# Altium schematic sheet Hierarchy.SchDoc
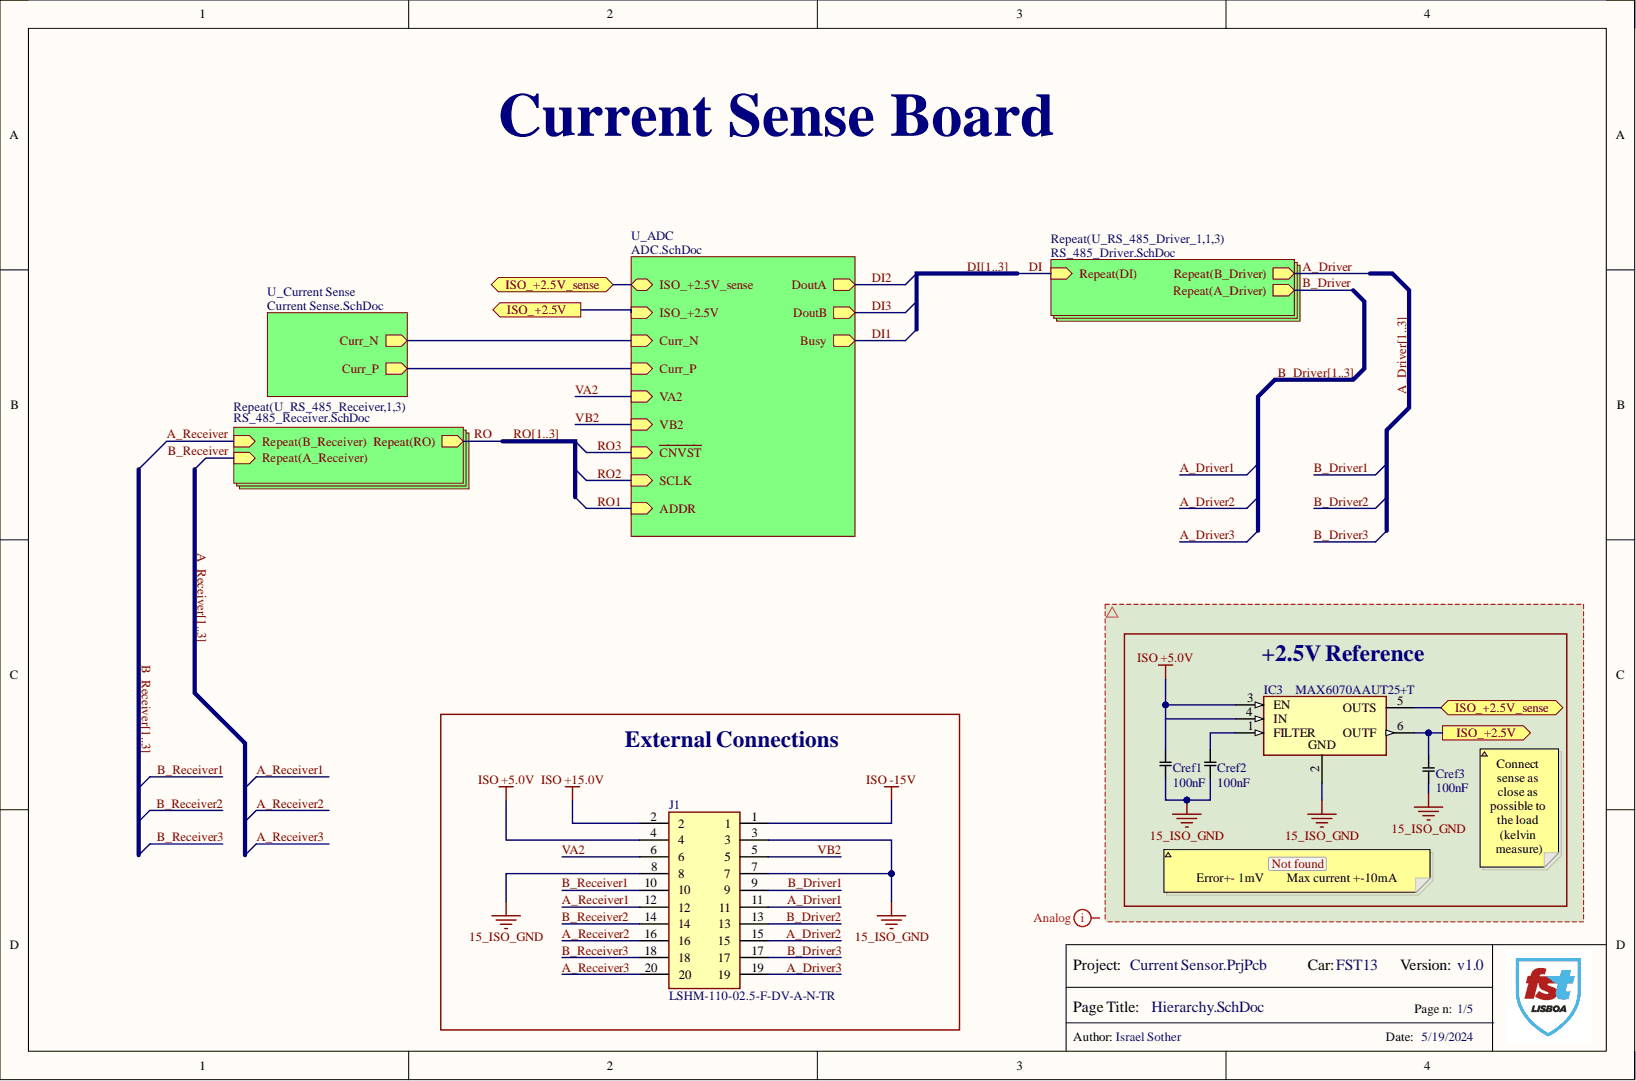
[diagram p0: Hierarchy.SchDoc]

## Parts
Cref1  Capacitance: 100nF  [0603]  (hidden comment: Capacitor 100nF 0603)
Cref2  Capacitance: 100nF  [0603]  (hidden comment: Capacitor 100nF 0603)
Cref3  Capacitance: 100nF  [0603]  (hidden comment: Capacitor 100nF 0603)
IC3  MAX6070AAUT25+T  [SOT95P280X145-6N]
J1  LSHM-110-02.5-F-DV-A-N-TR  [LSHM-110-XX.X-YYY-DV-A-N-TR]

## Ports
ISO_+2.5V, ISO_+2.5V_sense

## Nets
15_ISO_GND: Cref1.1 Cref2.1 Cref3.1 IC3.2 J1.3 J1.7 J1.8
ISO +5.0V: Cref1.2 IC3.3(EN) IC3.4(IN) J1.4
ISO +15.0V: J1.2
ISO -15V: J1.1
A_Driver1: J1.11  [also labelled A_Driver]
A_Driver2: J1.15  [also labelled A_Driver]
A_Driver3: J1.19  [also labelled A_Driver]
A_Receiver1: J1.12  [also labelled A_Receiver]
A_Receiver2: J1.16  [also labelled A_Receiver]
A_Receiver3: J1.20  [also labelled A_Receiver]
B_Driver1: J1.9  [also labelled B_Driver]
B_Driver2: J1.13  [also labelled B_Driver]
B_Driver3: J1.17  [also labelled B_Driver]
B_Receiver1: J1.10  [also labelled B_Receiver]
B_Receiver2: J1.14  [also labelled B_Receiver]
B_Receiver3: J1.18  [also labelled B_Receiver]
ISO_+2.5V: Cref3.2 IC3.6(OUTF) ; port ISO_+2.5V
ISO_+2.5V_sense: IC3.5(OUTS) ; port ISO_+2.5V_sense
VA2: J1.6
VB2: J1.5
NetCref2_2: Cref2.2 IC3.1(FILTER)
Curr_N:
Curr_P:
DI1:  [also labelled DI]
DI2:  [also labelled DI]
DI3:  [also labelled DI]
RO1:  [also labelled RO]
RO2:  [also labelled RO]
RO3:  [also labelled RO]

## Library data of the parts
Cref1-Cref3 = Capacitor: Component Kind: Standard; Description: Capacitor; Library Name: InverterSchematicLib.SchLib
IC3 = MAX6070AAUT25+T: Integrated Circuit; Manufacturer_Name: Analog Devices; Arrow Part Number: MAX6070AAUT25+T; Arrow Price/Stock: https://www.arrow.com/en/products/max6070aaut25t/maxim-integrated?region=nac; Component Kind: Standard; Datasheet Link: https://datasheets.maximintegrated.com/en/ds/MAX6070-MAX6071.pdf; Height: 1.45mm; Library Name: SamacSys.SchLib; Manufacturer_Part_Number: MAX6070AAUT25+T; Mouser Part Number: 700-MAX6070AAUT25+T; Mouser Price/Stock: https://www.mouser.co.uk/ProductDetail/Maxim-Integrated/MAX6070AAUT25%2bT?qs=CEvMt6SrWlLKXVYOZsN3AA%3D%3D
J1 = LSHM-110-02.5-F-DV-A-N-TR: Connector; Manufacturer_Name: SAMTEC; Component Kind: Standard; Datasheet Link: http://suddendocs.samtec.com/prints/lshm-1xx-xx.x-xxx-dv-a-x-x-xx-mkt.pdf; Library Name: SamacSys.SchLib; Manufacturer_Part_Number: LSHM-110-02.5-F-DV-A-N-TR; System: Connector
